# Altium schematic sheet PMI_Action_Octopus.SchDoc
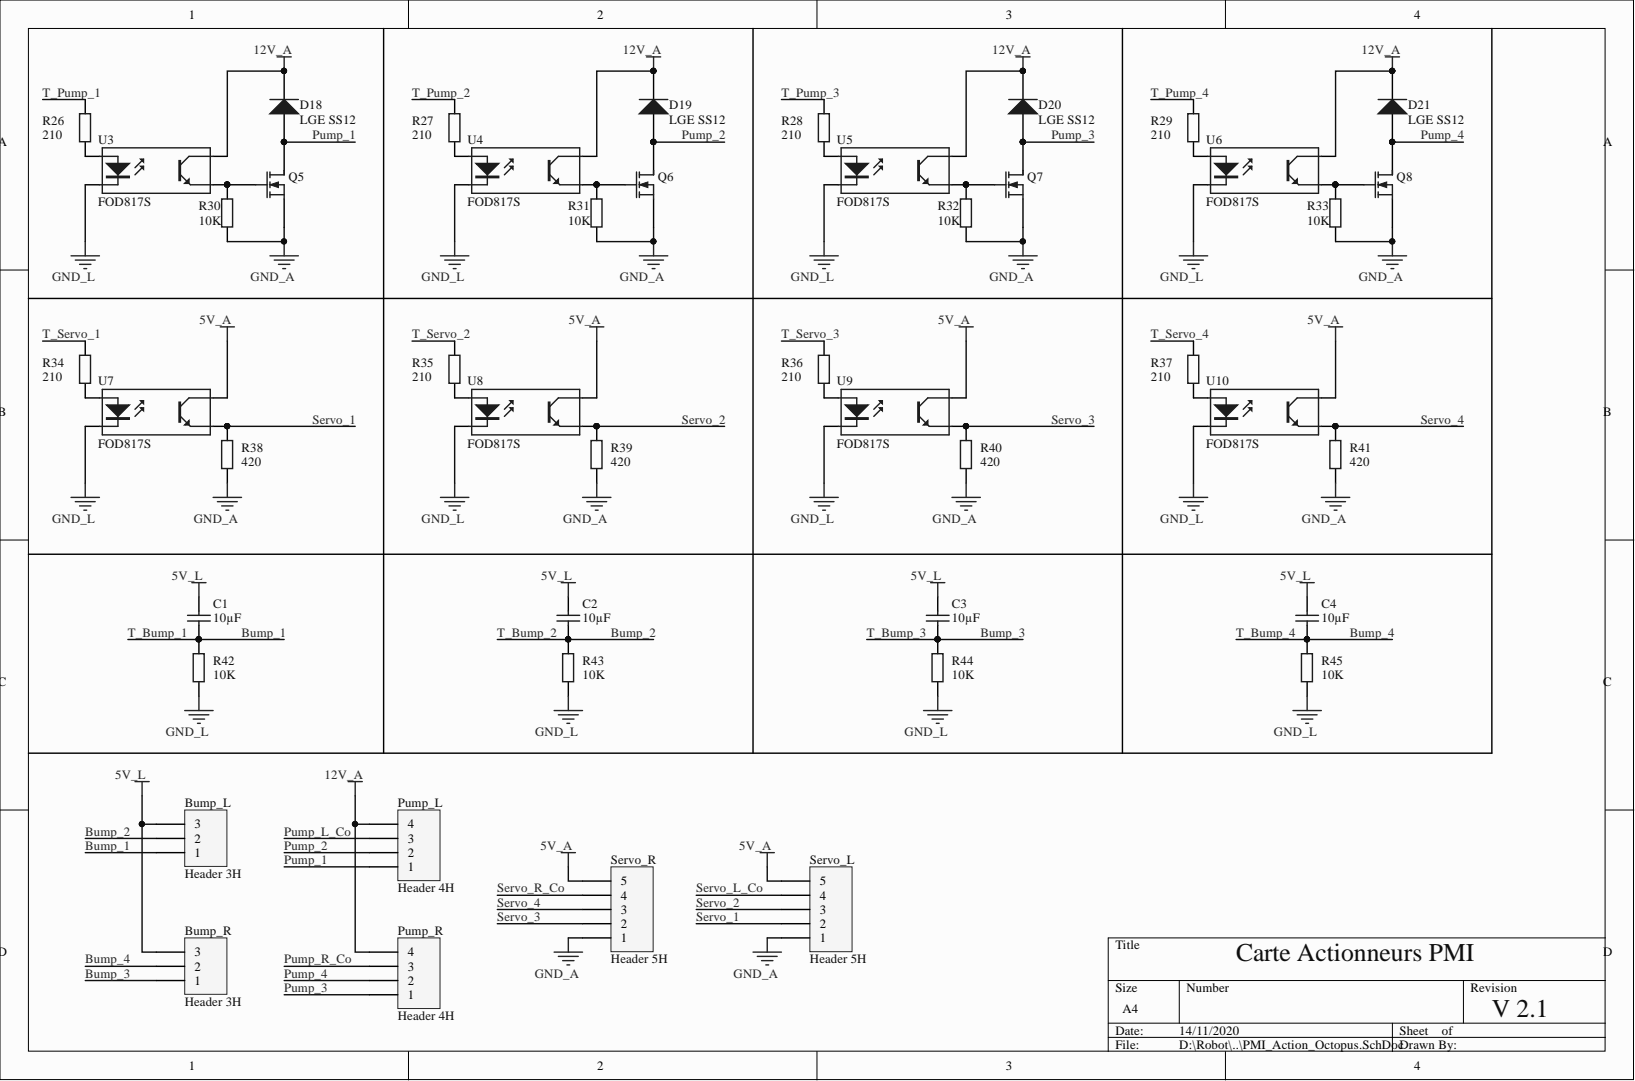
[diagram p0: PMI_Action_Octopus.SchDoc]

## Parts
Bump_L  Header 3H  [S3B-XH-A]
Bump_R  Header 3H  [S3B-XH-A]
C1  value 10µF  [Capacitor 1206]
C2  value 10µF  [Capacitor 1206]
C3  value 10µF  [Capacitor 1206]
C4  value 10µF  [Capacitor 1206]
D18  LGE SS12  [SMB]
D19  LGE SS12  [SMB]
D20  LGE SS12  [SMB]
D21  LGE SS12  [SMB]
Pump_L  Header 4H  [S4B-XH-A]
Pump_R  Header 4H  [S4B-XH-A]
Q5  MOSFET-N  [SOT23_M]  (value not drawn)
Q6  MOSFET-N  [SOT23_M]  (value not drawn)
Q7  MOSFET-N  [SOT23_M]  (value not drawn)
Q8  MOSFET-N  [SOT23_M]  (value not drawn)
R26  value 210  [Resistor 1206]  (hidden comment: Res2)
R27  value 210  [Resistor 1206]  (hidden comment: Res2)
R28  value 210  [Resistor 1206]  (hidden comment: Res2)
R29  value 210  [Resistor 1206]  (hidden comment: Res2)
R30  value 10K  [Resistor 1206]  (hidden comment: Res2)
R31  value 10K  [Resistor 1206]  (hidden comment: Res2)
R32  value 10K  [Resistor 1206]  (hidden comment: Res2)
R33  value 10K  [Resistor 1206]  (hidden comment: Res2)
R34  value 210  [Resistor 1206]  (hidden comment: Res2)
R35  value 210  [Resistor 1206]  (hidden comment: Res2)
R36  value 210  [Resistor 1206]  (hidden comment: Res2)
R37  value 210  [Resistor 1206]  (hidden comment: Res2)
R38  value 420  [Resistor 1206]  (hidden comment: Res2)
R39  value 420  [Resistor 1206]  (hidden comment: Res2)
R40  value 420  [Resistor 1206]  (hidden comment: Res2)
R41  value 420  [Resistor 1206]  (hidden comment: Res2)
R42  value 10K  [Resistor 1206]  (hidden comment: Res2)
R43  value 10K  [Resistor 1206]  (hidden comment: Res2)
R44  value 10K  [Resistor 1206]  (hidden comment: Res2)
R45  value 10K  [Resistor 1206]  (hidden comment: Res2)
Servo_L  Header 5H  [S5B-XH-A]
Servo_R  Header 5H  [S5B-XH-A]
U3  FOD817S  [SOP254P1016X385-4N]
U4  FOD817S  [SOP254P1016X385-4N]
U5  FOD817S  [SOP254P1016X385-4N]
U6  FOD817S  [SOP254P1016X385-4N]
U7  FOD817S  [SOP254P1016X385-4N]
U8  FOD817S  [SOP254P1016X385-4N]
U9  FOD817S  [SOP254P1016X385-4N]
U10  FOD817S  [SOP254P1016X385-4N]

## Nets
5V_A: Servo_L.5 Servo_R.5 U7.4 U8.4 U9.4 U10.4
5V_L: Bump_L.3 Bump_R.3 C1.2 C2.2 C3.2 C4.2
12V_A: D18.2 D19.2 D20.2 D21.2 Pump_L.4 Pump_R.4 U3.4 U4.4 U5.4 U6.4
GND_A: Q5.3 Q6.3 Q7.3 Q8.3 R30.1 R31.1 R32.1 R33.1 R38.1 R39.1 R40.1 R41.1 Servo_L.1 Servo_R.1
GND_L: R42.1 R43.1 R44.1 R45.1 U3.2 U4.2 U5.2 U6.2 U7.2 U8.2 U9.2 U10.2
BUMP_1: Bump_L.1 C1.1 R42.2
BUMP_2: Bump_L.2 C2.1 R43.2
BUMP_3: Bump_R.1 C3.1 R44.2
BUMP_4: Bump_R.2 C4.1 R45.2
PUMP_1: D18.1 Pump_L.1 Q5.1
PUMP_2: D19.1 Pump_L.2 Q6.1
PUMP_3: D20.1 Pump_R.1 Q7.1
PUMP_4: D21.1 Pump_R.2 Q8.1
PUMP_L_CO: Pump_L.3
PUMP_R_CO: Pump_R.3
SERVO_1: R38.2 Servo_L.2 U7.3
SERVO_2: R39.2 Servo_L.3 U8.3
SERVO_3: R40.2 Servo_R.2 U9.3
SERVO_4: R41.2 Servo_R.3 U10.3
SERVO_L_CO: Servo_L.4
SERVO_R_CO: Servo_R.4
T_PUMP_1: R26.2
T_PUMP_2: R27.2
T_PUMP_3: R28.2
T_PUMP_4: R29.2
T_SERVO_1: R34.2
T_SERVO_2: R35.2
T_SERVO_3: R36.2
T_SERVO_4: R37.2
NetQ5_2: Q5.2 R30.2 U3.3
NetQ6_2: Q6.2 R31.2 U4.3
NetQ7_2: Q7.2 R32.2 U5.3
NetQ8_2: Q8.2 R33.2 U6.3
NetR26_1: R26.1 U3.1
NetR27_1: R27.1 U4.1
NetR28_1: R28.1 U5.1
NetR29_1: R29.1 U6.1
NetR34_1: R34.1 U7.1
NetR35_1: R35.1 U8.1
NetR36_1: R36.1 U9.1
NetR37_1: R37.1 U10.1

## Library data of the parts
Bump_L Bump_R = Header 3H: Header, 3-Pin, Right Angle; Component Kind: Standard; LatestRevisionDate: 17-Jul-2002; LatestRevisionNote: Re-released for DXP Platform.; Library Name: Miscellaneous Connectors.IntLib; Signal Integrity: Connector; Publisher: Altium Limited
C1-C4 = Cap: Capacitor; Component Kind: Standard; LatestRevisionDate: 17-Jul-2002; LatestRevisionNote: Re-released for DXP Platform.; Library Name: Miscellaneous Devices.IntLib; PackageDescription: Radial Cap, Thru-Hole; 2 Leads; 0.3 in Pin Spacing; PackageReference: RAD-0.3; Published: 8-Jun-2000; Signal Integrity: Cap; Simulation: CAP; Publisher: Altium Limited
D18-D21 = Diode: Default Diode; Code_JEDEC: DO-214-AB; Component Kind: Standard; LatestRevisionDate: 17-Jul-2002; LatestRevisionNote: Re-released for DXP Platform.; Library Name: Miscellaneous Devices.IntLib; PackageDescription: DO-214-AB/SMC; 2 C-Bend Leads; Body 7.9 x 5.9 mm, inc. leads (LxW); PackageReference: SMC; PackageVersion: Sep-1996; Published: 8-Jun-2000; Signal Integrity: Diode; Simulation: DIODE; Publisher: Altium Limited
Pump_L Pump_R = Header 4H: Header, 4-Pin, Right Angle; Component Kind: Standard; LatestRevisionDate: 17-Jul-2002; LatestRevisionNote: Re-released for DXP Platform.; Library Name: Miscellaneous Connectors.IntLib; Signal Integrity: Connector; Publisher: Altium Limited
Q5-Q8 = MOSFET-N: N-Channel MOSFET; Component Kind: Standard; LatestRevisionDate: 17-Jul-2002; LatestRevisionNote: Re-released for DXP Platform.; Library Name: Miscellaneous Devices.IntLib; PackageDescription: TO, Flat Index; 3 In-Line, Axial Leads; Body Dia. 4.6mm; Lead Dia. 0.5 mm (max); PackageReference: E3; PackageVersion: 1995/1996; Published: 8-Jun-2000; Simulation: NMOS; Publisher: Altium Limited
R26-R45 = Res2: Resistor; Component Kind: Standard; LatestRevisionDate: 17-Jul-2002; LatestRevisionNote: Re-released for DXP Platform.; Library Name: Miscellaneous Devices.IntLib; PackageDescription: Axial Device, Thru-Hole; 2 Leads; 0.4 in Pin Spacing; PackageReference: AXIAL-0.4; Published: 8-Jun-2000; Signal Integrity: Res; Simulation: RESISTOR; Publisher: Altium Limited
Servo_L Servo_R = Header 5H: Header, 5-Pin, Right Angle; Component Kind: Standard; LatestRevisionDate: 17-Jul-2002; LatestRevisionNote: Re-released for DXP Platform.; Library Name: Miscellaneous Connectors.IntLib; Signal Integrity: Connector; Publisher: Altium Limited
U3-U10 = Optoisolator1: 4-Pin Phototransistor Optocoupler; Component Kind: Standard; DatasheetVersion: Nov-2003; LatestRevisionDate: 04-Mar-2005; LatestRevisionNote: Sch pins 3 & 4 Swapped.; Library Name: Miscellaneous Devices.IntLib; PackageReference: DIP-4; Published: 24-Mar-1999; Publisher: Altium Limited
